# Altium schematic sheet Top-Level.SchDoc(Top-Level)
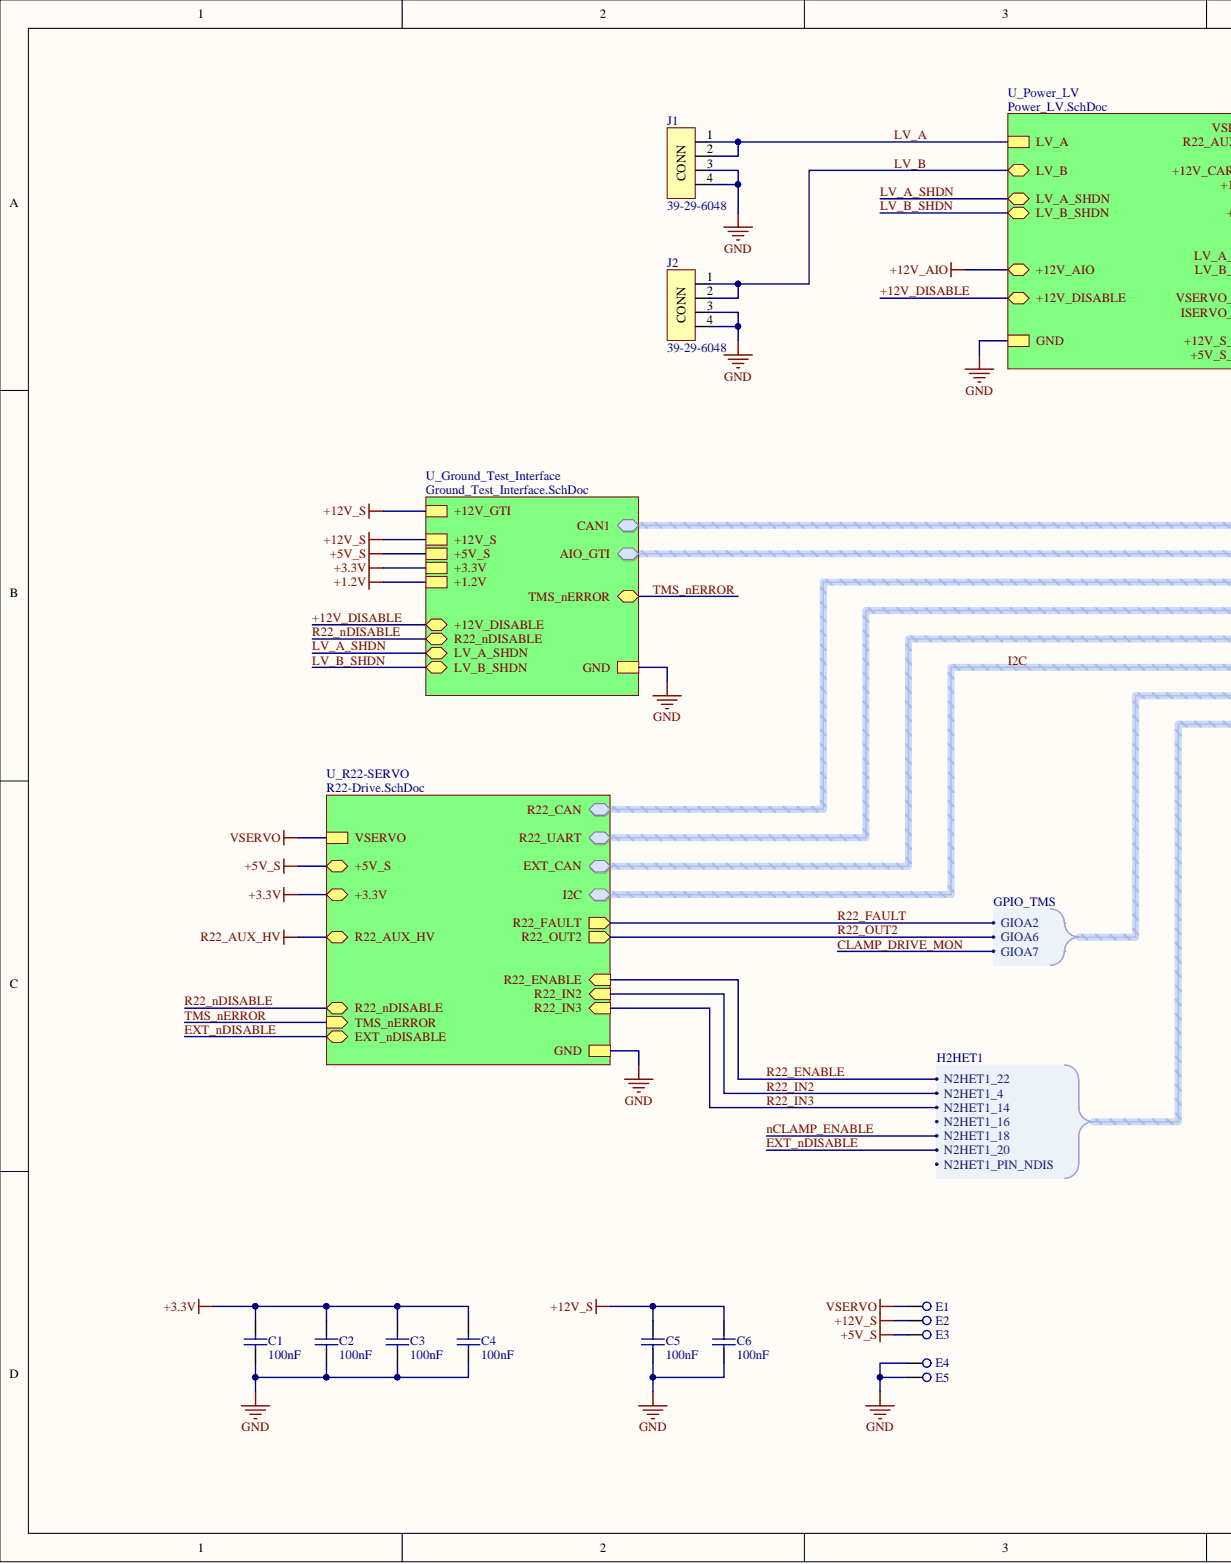
[diagram p0: Top-Level.SchDoc, left]
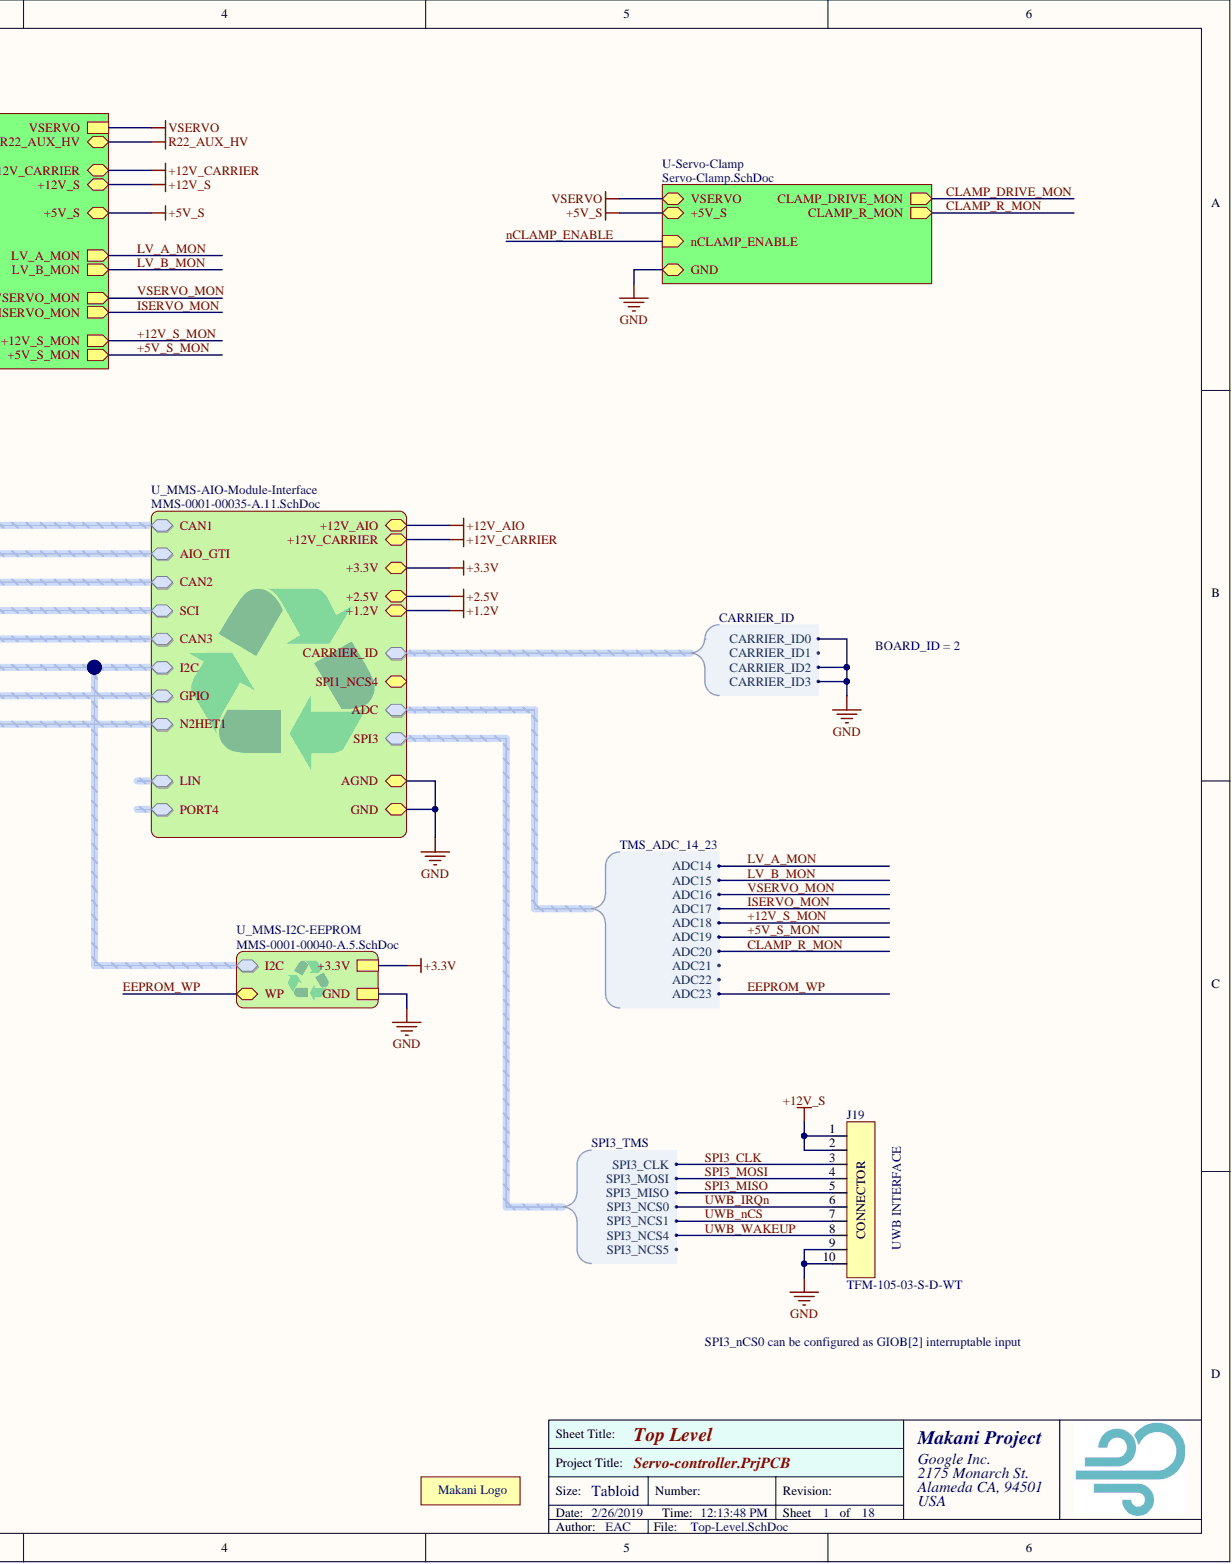
[diagram p1: Top-Level.SchDoc, right]

## Parts
*1  Makani Logo  [MAKANI-LOGO-200MIL]  (no BOM)
C1  value 100nF  [CAPC0603(1608)100_N]  (hidden comment: CAP 100nF 25V 0603(1608))
C2  value 100nF  [CAPC0603(1608)100_N]  (hidden comment: CAP 100nF 25V 0603(1608))
C3  value 100nF  [CAPC0603(1608)100_N]  (hidden comment: CAP 100nF 25V 0603(1608))
C4  value 100nF  [CAPC0603(1608)100_N]  (hidden comment: CAP 100nF 25V 0603(1608))
C5  value 100nF  [CAPC0603(1608)100_N]  (hidden comment: CAP 100nF 25V 0603(1608))
C6  value 100nF  [CAPC0603(1608)100_N]  (hidden comment: CAP 100nF 25V 0603(1608))
E1  5015  [TP-5015]  (value not drawn)
E2  5015  [TP-5015]  (value not drawn)
E3  5015  [TP-5015]  (value not drawn)
E4  5019  [TP-5019]  (value not drawn)
E5  5019  [TP-5019]  (value not drawn)
J1  39-29-6048  [MOLX-39-29-6048 - duplicate]
J2  39-29-6048  [MOLX-39-29-6048 - duplicate]
J19  TFM-105-03-S-D-WT  [SAM-TFM-105-XX-X-D-WT - duplicate]

## Nets
+3.3V: C1.2 C2.2 C3.2 C4.2
+5V_S: E3.1
+12V_S: C5.2 C6.2 E2.1 J19.1 J19.2
GND: C1.1 C2.1 C3.1 C4.1 C5.1 C6.1 E4.1 E5.1 J1.3 J1.4 J2.3 J2.4 J19.9 J19.10
VSERVO: E1.1
LV_A_1: J1.1 J1.2  [also labelled LV_A]
LV_B_1: J2.1 J2.2  [also labelled LV_B]
SPI3_CLK_1: J19.3  [also labelled SPI3_CLK]
SPI3_MISO_1: J19.5  [also labelled SPI3_MISO]
SPI3_MOSI_1: J19.4  [also labelled SPI3_MOSI]
UWB_IRQn_1: J19.6  [also labelled UWB_IRQn]
UWB_nCS_1: J19.7  [also labelled UWB_nCS]
UWB_WAKEUP_1: J19.8  [also labelled UWB_WAKEUP]
+1.2V:
+2.5V:
+5V_S_MON_1:  [also labelled +5V_S_MON]
+12V_AIO:
+12V_CARRIER:
+12V_DISABLE_1:  [also labelled +12V_DISABLE]
+12V_S_MON_1:  [also labelled +12V_S_MON]
CLAMP_DRIVE_MON_1:  [also labelled CLAMP_DRIVE_MON]
CLAMP_R_MON_1:  [also labelled CLAMP_R_MON]
EEPROM_WP_1:  [also labelled EEPROM_WP]
EXT_nDISABLE_1:  [also labelled EXT_nDISABLE]
I2C.I2C_SCL_1:  [also labelled I2C.I2C_SCL]
I2C.I2C_SDA_1:  [also labelled I2C.I2C_SDA]
ISERVO_MON_1:  [also labelled ISERVO_MON]
LV_A_MON_1:  [also labelled LV_A_MON]
LV_A_SHDN_1:  [also labelled LV_A_SHDN]
LV_B_MON_1:  [also labelled LV_B_MON]
LV_B_SHDN_1:  [also labelled LV_B_SHDN]
N00419:
N00420:
N00421:
N00422:
N00423:
N00424:
N00425:
N00426:
N00427:
N00428:
N00429:
N00430:
N00431:
N00432:
N00433:
N00434:
N00435:
N00436:
N00437:
N00438:
N00439:
N00440:
N00441:
N00442:
N00443:
N00444:
N00445:
N00446:
N00447:
N00448:
N00449:
nCLAMP_ENABLE_1:  [also labelled nCLAMP_ENABLE]
R22_AUX_HV:
R22_ENABLE_1:  [also labelled R22_ENABLE]
R22_FAULT_1:  [also labelled R22_FAULT]
R22_IN2_1:  [also labelled R22_IN2]
R22_IN3_1:  [also labelled R22_IN3]
R22_nDISABLE_1:  [also labelled R22_nDISABLE]
R22_OUT2_1:  [also labelled R22_OUT2]
TMS_nERROR_1:  [also labelled TMS_nERROR]
VSERVO_MON_1:  [also labelled VSERVO_MON]

## Library data of the parts
*1 = CMP-0014-00000-2: Layer: Silk; Size: 200mil; Description: Makani Logo; Library Name: Makani Vault(migrated)
C1-C6 = CMP-0002-00445-7: CAP 100nF 25V ±10% 0603 (1608 Metric) Thickness 1mm SMD; Temp Range: -55°C ~ 125°C; Mfg P/N: CL10B104KA8WPNC; Mfg: Samsung; GPN: 150-00502-00; Case-Metric: 1608; Case-EIA: 0603; Tolerance: ±10%; Technology: SMT; Supplier 1 P/N: 1276-6717-1-ND; Supplier 1: DigiKey; Rated Voltage: 25 V; Max Thickness: 1 mm; Component Kind: Standard; Library Name: Makani Vault(migrated)
E1-E3 = CMP-0008-00001-5: Keystone 5015 Micro SMT Test Point; Mfg: Keystone Electronics Corp.; GPN: 250-00207-00; Supplier 1 P/N: 36-5015CT-ND; Supplier 1: Digikey; Mfg P/N: 5015; HelpURL: http://www.keyelco.com/product.cfm/Micro-Miniature/5015/product_id/1353; Component Kind: Standard; Library Name: Makani Vault(migrated)
E4 E5 = CMP-0008-00002-5: Keystone 5019 Mininature SMT Test Point; Mfg: Keystone Electronics Corp.; GPN: 250-00208-00; Supplier 1 P/N: 36-5019CT-ND; Supplier 1: Digikey; Mfg P/N: 5019; HelpURL: http://www.keyelco.com/product.cfm/Miniature/5019/product_id/1357; Component Kind: Standard; Library Name: Makani Vault(migrated)
J1 J2 = CMP-0180-00001-5: Mini-Fit Jr, Vertical, Dual row, 4 pin; Rows: 2; Pitch: 4.2; Mfg: MOLEX; GPN: 210-00759-00; Contact Thickness: 0.38; Circuits: 4; Termination: Through Hole; Supplier 1 P/N: WM23821-ND; Supplier 1: DigiKey; Orientation: Vertical; Mfg P/N: 39-29-6048; HelpURL: http://www.molex.com/pdm_docs/sd/039281103_sd.pdf; Contact Finish: Gold; Component Kind: Standard; Library Name: Makani Vault(migrated)
J19 = CMP-0145-00005-6: 50mill 5pos Double Row Vertical Male Header; Weld Tab: Yes; Positions: 10; Pin Length: 2.82mm; Mfg: Samtec Inc.; GPN: 210-01111-00; Termination: TH; Supplier 1 P/N: TFM-105-03-S-D-WT; Supplier 1: Samtec Inc.; Orientation: Vertical; Mfg P/N: TFM-105-03-S-D-WT; HelpURL: http://www.samtec.com/documents/webfiles/cpdf/TFM-1XX-XX-XXX-D-XXX-MKT.pdf; Component Kind: Standard; Library Name: Makani Vault(migrated)
